# Altium schematic sheet WiFarm.SchDoc
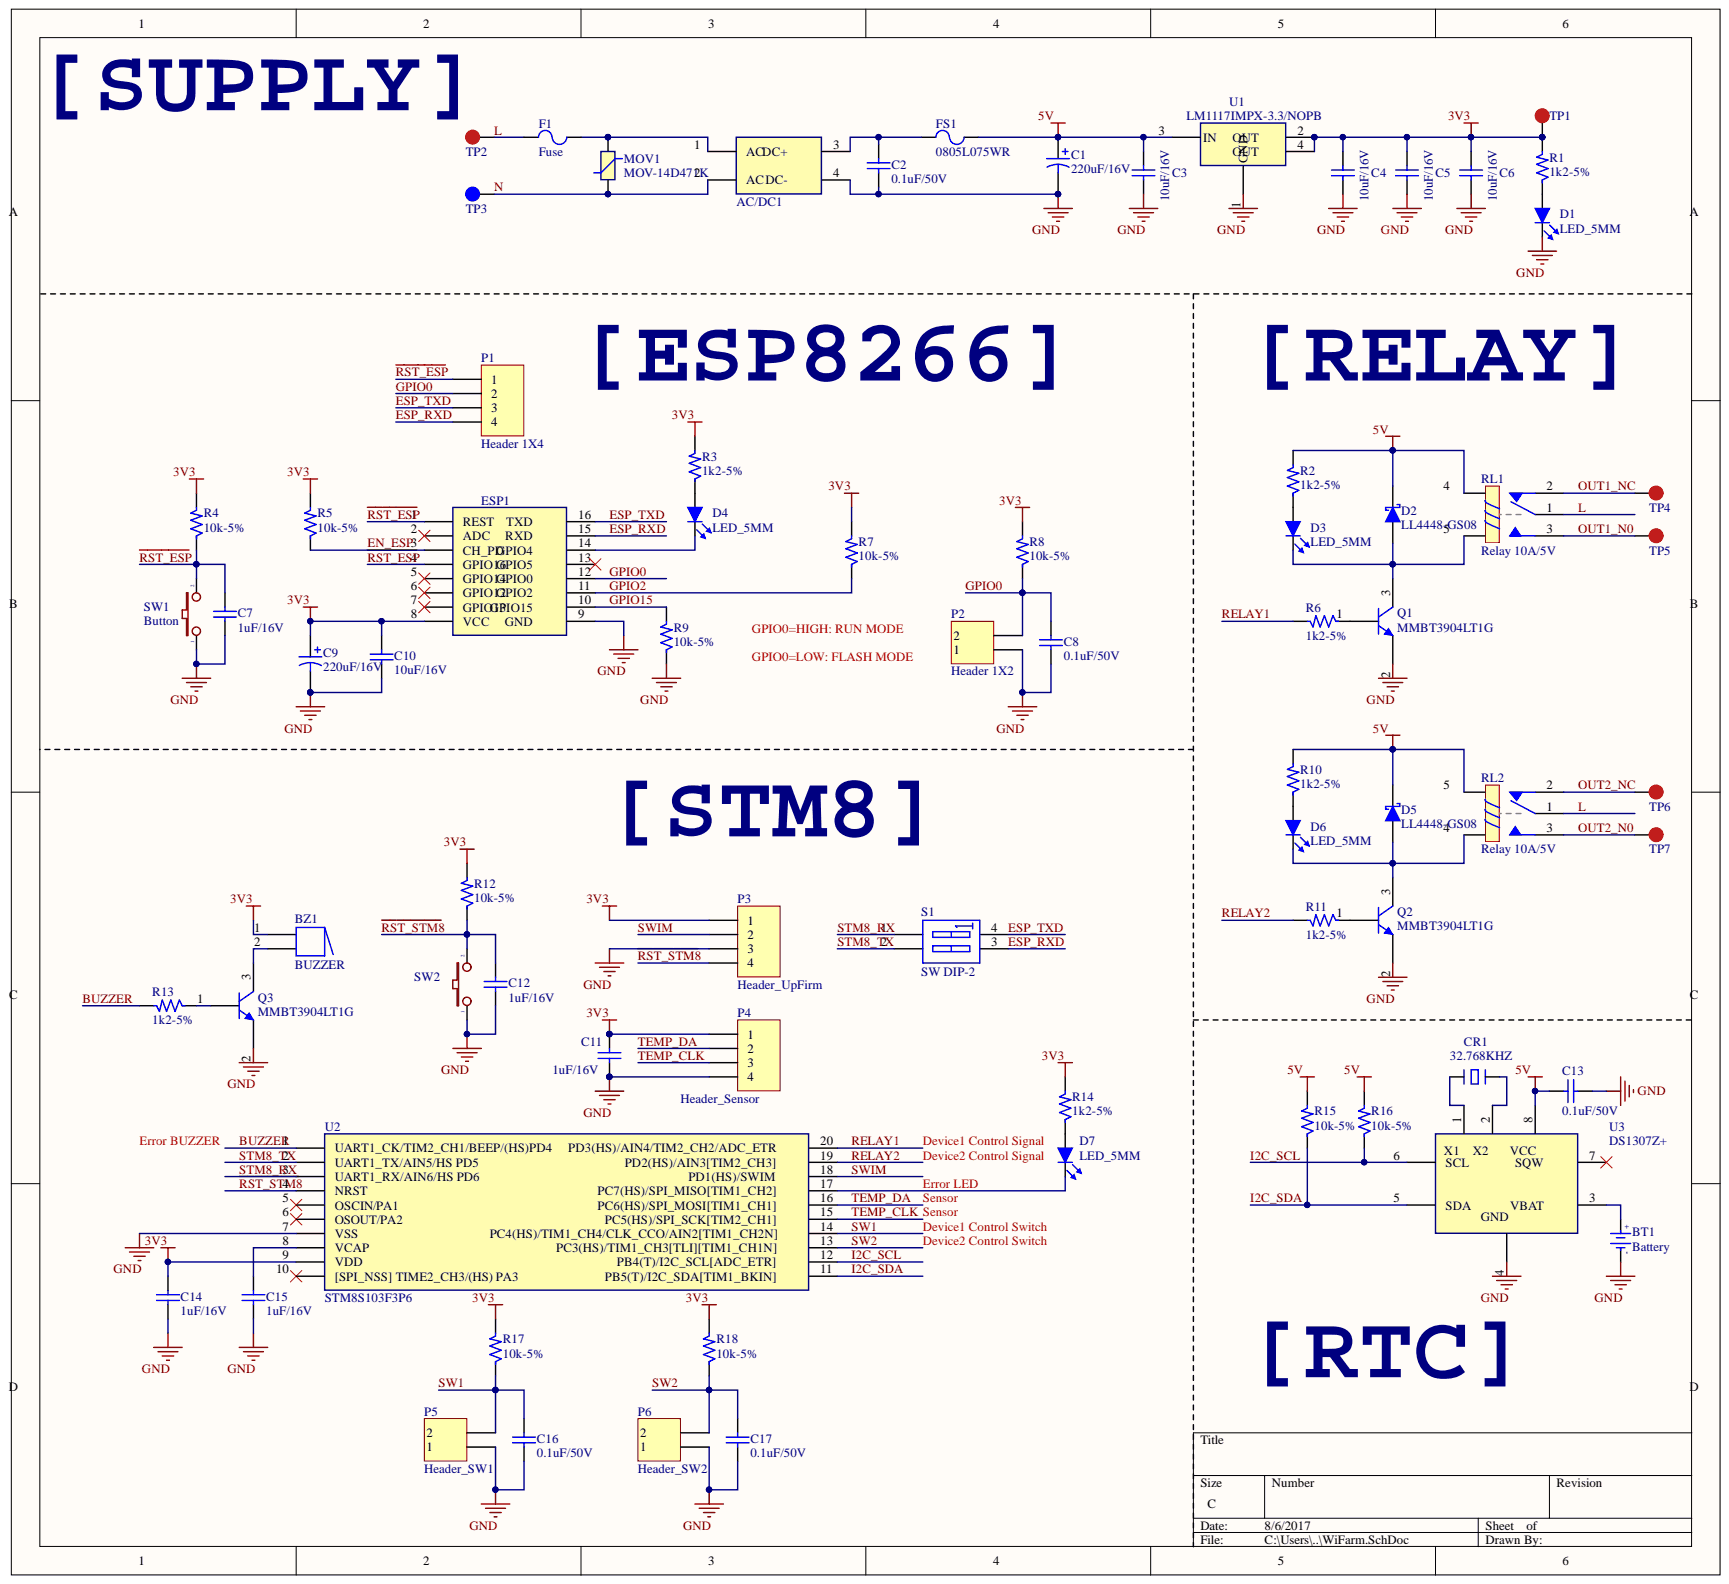
[diagram p0: WiFarm.SchDoc]

## Parts
AC/DC1  AC/DC_5V_0.7A  [AC/DC_5V_700mA]  (value not drawn)
BT1  Battery  [BATTERY HOLDER 1]
BZ1  BUZZER  [buzzer smd]
C1  220uF/16V  [RADIAL_6.3X12]
C2  0.1uF/50V  [CAP_SMD_0805]
C3  10uF/16V  [CAP_SMD_0805]
C4  10uF/16V  [CAP_SMD_0805]
C5  10uF/16V  [CAP_SMD_0805]
C6  10uF/16V  [CAP_SMD_0805]
C7  1uF/16V  [CAP_SMD_0805]
C8  0.1uF/50V  [CAP_SMD_0805]
C9  220uF/16V  [RADIAL_6.3X12]
C10  10uF/16V  [CAP_SMD_0805]
C11  1uF/16V  [CAP_SMD_0805]
C12  1uF/16V  [CAP_SMD_0805]
C13  0.1uF/50V  [CAP_SMD_0805]
C14  1uF/16V  [CAP_SMD_0805]
C15  1uF/16V  [CAP_SMD_0805]
C16  0.1uF/50V  [CAP_SMD_0805]
C17  0.1uF/50V  [CAP_SMD_0805]
CR1  32.768KHZ  [Cylindrical crystal - small]
D1  LED_5MM  [LED_5mm]
D2  LL4448-GS08  [SOD80C]
D3  LED_5MM  [LED_5mm]
D4  LED_5MM  [LED_5mm]
D5  LL4448-GS08  [SOD80C]
D6  LED_5MM  [LED_5mm]
D7  LED_5MM  [LED_5mm]
ESP1  ESP8266_07  [ESP8266_07]  (value not drawn)
F1  Fuse  [RESISTOR 1/4W (STAND)]
FS1  0805L075WR  [RES_SMD_0805]
MOV1  MOV-14D471K  [14D471]
P1  Header 1X4  [Header 1X4]
P2  Header 1X2  [Header 1X2]
P3  Header_UpFirm  [Header 1X4]
P4  Header_Sensor  [Header 1X4]
P5  Header_SW1  [Header 1X2]
P6  Header_SW2  [Header 1X2]
Q1  MMBT3904LT1G  [SOT-23]
Q2  MMBT3904LT1G  [SOT-23]
Q3  MMBT3904LT1G  [SOT-23]
R1  1k2-5%  [RES_SMD_0805]
R2  1k2-5%  [RES_SMD_0805]
R3  1k2-5%  [RES_SMD_0805]
R4  10k-5%  [RES_SMD_0805]
R5  10k-5%  [RES_SMD_0805]
R6  1k2-5%  [RES_SMD_0805]
R7  10k-5%  [RES_SMD_0805]
R8  10k-5%  [RES_SMD_0805]
R9  10k-5%  [RES_SMD_0805]
R10  1k2-5%  [RES_SMD_0805]
R11  1k2-5%  [RES_SMD_0805]
R12  10k-5%  [RES_SMD_0805]
R13  1k2-5%  [RES_SMD_0805]
R14  1k2-5%  [RES_SMD_0805]
R15  10k-5%  [RES_SMD_0805]
R16  10k-5%  [RES_SMD_0805]
R17  10k-5%  [RES_SMD_0805]
R18  10k-5%  [RES_SMD_0805]
RL1  Relay 10A/5V  [RELAY5PIN-10A]
RL2  Relay 10A/5V  [RELAY5PIN-10A]
S1  SW DIP-2  [DIP SWITCH 2]
SW1  Button  [BUTTON_SMD_2PIN]
SW2  SW_Reset  [BUTTON_SMD_2PIN]  (value not drawn)
TP1  Test Point  (value not drawn)
TP2  Test Point  (value not drawn)
TP3  Test Point  (value not drawn)
TP4  Test Point  (value not drawn)
TP5  Test Point  (value not drawn)
TP6  Test Point  (value not drawn)
TP7  Test Point  (value not drawn)
U1  LM1117IMPX-3.3/NOPB  [SOT223]
U2  STM8S103F3P6  [TSSOP20]
U3  DS1307Z+  [SOIC8_MOSFET]

## Nets
3V3: BZ1.1 C4.2 C5.2 C6.2 C9.1 C10.2 C11.2 C14.2 ESP1.8(VCC) P3.1 P4.1 R1.2 R3.2 R4.2 R5.2 R7.2 R8.2 R12.2 R14.2 R17.2 R18.2 TP1.1 U1.2 U1.4 U2.9(VDD)
5V: C1.1 C3.2 C13.2 D2.2 D5.2 FS1.2 R2.2 R10.2 R15.2 R16.2 RL1.4 RL2.5 U1.3(IN) U3.8
GND: AC/DC1.4 BT1.2 C1.2 C2.1 C3.1 C4.1 C5.1 C6.1 C7.1 C8.1 C9.2 C10.1 C11.1 C12.1 C13.1 C14.1 C15.1 C16.1 C17.1 D1.2 ESP1.9 P2.1 P3.3 P4.4 P5.1 P6.1 Q1.2 Q2.2 Q3.2 R9.1 SW1.1 SW2.1 U1.1(GND) U2.7(VSS) U3.4
BUZZER: R13.2 U2.1(UART1_CK/TIM2_CH1/BEEP/(HS)PD4)
EN_ESP: ESP1.3(CH_PD) R5.1
ESP_RXD: ESP1.15 P1.4 S1.3
ESP_TXD: ESP1.16 P1.3 S1.4
GPIO0: C8.2 ESP1.12 P1.2 P2.2 R8.1
GPIO2: ESP1.11 R7.1
GPIO15: ESP1.10 R9.2
I2C_SCL: R16.1 U2.12 U3.6(SCL)
I2C_SDA: R15.1 U2.11 U3.5(SDA)
L: F1.1 RL1.1 RL2.1 TP2.1
N: AC/DC1.2(AC_DC-) MOV1.1 TP3.1
OUT1_N0: RL1.3 TP5.1
OUT1_NC: RL1.2 TP4.1
OUT2_N0: RL2.3 TP7.1
OUT2_NC: RL2.2 TP6.1
~{RST_ESP}: C7.2 ESP1.1(REST) ESP1.4(GPIO16) P1.1 R4.1 SW1.2
~{RST_STM8}: C12.2 P3.4 R12.1 SW2.2 U2.4(NRST)
RELAY1: R6.2 U2.20
RELAY2: R11.2 U2.19
STM8_RX: S1.1 U2.3(UART1_RX/AIN6/HS_PD6)
STM8_TX: S1.2 U2.2(UART1_TX/AIN5/HS_PD5)
SW1: C16.2 P5.2 R17.1 U2.14
SW2: C17.2 P6.2 R18.1 U2.13
SWIM: P3.2 U2.18
TEMP_CLK: P4.3 U2.15
TEMP_DA: P4.2 U2.16
NetAC/DC1_1: AC/DC1.1(AC) F1.2 MOV1.2
NetAC/DC1_3: AC/DC1.3 C2.2 FS1.1
NetBT1_1: BT1.1 U3.3
NetBZ1_2: BZ1.2 Q3.3
NetC15_2: C15.2 U2.8(VCAP)
NetCR1_1: CR1.1 U3.2
NetCR1_2: CR1.2 U3.1
NetD1_1: D1.1 R1.1
NetD2_1: D2.1 D3.2 Q1.3 RL1.5
NetD3_1: D3.1 R2.1
NetD4_1: D4.1 R3.1
NetD4_2: D4.2 ESP1.14
NetD5_1: D5.1 D6.2 Q2.3 RL2.4
NetD6_1: D6.1 R10.1
NetD7_1: D7.1 R14.1
NetD7_2: D7.2 U2.17
NetQ1_1: Q1.1 R6.1
NetQ2_1: Q2.1 R11.1
NetQ3_1: Q3.1 R13.1

Not connected: ESP1 2(ADC) 5(GPIO14) 6(GPIO12) 7(GPIO13) 13; U2 5(OSCIN/PA1) 6(OSOUT/PA2) 10([SPI_NSS]_TIME2_CH3/(HS)_PA3); U3 7

## Library data of the parts
AC/DC1 = AC/DC_5V_0.7A: AC/DC Converter 5V 700mA; Component Kind: Standard; ComponentLink1URL: http://www.dientuachau.com/ac-dc-5v-700; Library Name: LIF_DIF_Library.IntLib
BT1 = Battery: Battery 3VDC; Value: PIN 3V; Component Kind: Standard; Library Name: LIF_DIF_Library.IntLib
BZ1 = BUZZER: AUDIO MAGNETIC XDCR 3-7V TH; Manufacturer: PUI Audio, Inc.; Manufacturer Part Number: AT-1224-TWT-5V-2-R; Current - Supply: 40mA; Driver Circuitry: Transducer, Externally Driven; Frequency: 2.4kHz; Input Type: Peak-Peak Signal; Operating Mode: Single Tone; Port Location: Top; Ratings: Washable; Sound Pressure Level (SPL): 87dB @ 5V, 10cm; Voltage - Rated: 5V; Voltage Range: 3 ~ 7V; Approvals: -; Category: Audio Products; Component Kind: Standard; ComponentLink1Description: http://www.puiaudio.com/pdf/AT-1224-TWT-5V-2-R.pdf; ComponentLink1URL: http://www.puiaudio.com/pdf/AT-1224-TWT-5V-2-R.pdf; Duration: -; Height - Seated (Max): 0.366" (9.30mm); Mounting Type: Through Hole; Operating Temperature: -30°C ~ 70°C; Packaging: Tray; Part Status: Active; Pricing 1: 1=0.55, 10=0.5, 100=0.4, 500=0.332, 1000=0.29, 5000=0.26 (USD); RoHS: RoHS Compliant; Size / Dimension: 0.472" Dia (12.00mm); Stock 1: 54169; Supplier 1: Digi-Key; Supplier Part Number 1: 668-1470-ND; Technology: Magnetic; Termination: PC Pins; Library Name: LIF_DIF_Library.IntLib
C1 C9 = 100uF/25V: CAP ALUM 100UF 20% 25V RADIAL; Manufacturer: Panasonic Electronic Components; Manufacturer Part Number: ECA-1EM101; Capacitance: 100µF; Lifetime @ Temp.: 2000 Hrs @ 85°C; Polarization: Polar; Ripple Current - High Frequency: 306mA @ 10kHz; Ripple Current - Low Frequency: 180mA @ 120Hz; Applications: General Purpose; Category: Capacitors; Component Kind: Standard; ComponentLink1Description: https://industrial.panasonic.com/cdbs/www-data/pdf/RDF0000/ABA0000C1218.pdf; ComponentLink1URL: https://industrial.panasonic.com/cdbs/www-data/pdf/RDF0000/ABA0000C1218.pdf; ESR (Equivalent Series Resistance): -; Height - Seated (Max): 0.480" (12.20mm); Impedance: -; Lead Spacing: 0.098" (2.50mm); Mounting Type: Through Hole; Operating Temperature: -40°C ~ 85°C; Package / Case: Radial, Can; Packaging: Bulk; Part Status: Active; Pricing 1: 1=0.28, 10=0.191, 100=0.097, 500=0.06634, 1000=0.05868, 2500=0.05613, 5000=0.05103 (USD); RoHS: RoHS Compliant; Size / Dimension: 0.248" Dia (6.30mm); Stock 1: 8044; Supplier 1: Digi-Key; Supplier Part Number 1: P5152-ND; Surface Mount Land Size: -; Tolerance: ±20%; Voltage Rating: 25V; Library Name: LIF_DIF_Library.IntLib
C2 C8 C13 C16 C17 = 0.1uF/50V-10%-0805: CAP CER 0.1UF 50V X7R 0805; Manufacturer: Yageo; Manufacturer Part Number: CC0805KRX7R9BB104; Capacitance: 0.10µF; Tolerance: ±10%; Applications: General Purpose; Category: Capacitors; Component Kind: Standard; ComponentLink1Description: http://www.yageo.com/documents/recent/UPY-GPHC_X7R_6.3V-to-50V_14.pdf; ComponentLink1URL: http://www.yageo.com/documents/recent/UPY-GPHC_X7R_6.3V-to-50V_14.pdf; Features: -; Height - Seated (Max): -; Lead Spacing: -; Lead Style: -; Mounting Type: Surface Mount, MLCC; Operating Temperature: -55°C ~ 125°C; Package / Case: 0805 (2012 Metric); Packaging: Cut Tape (CT); Pricing 1: 1=0.1, 10=0.051, 25=0.0356, 100=0.0232, 250=0.01896, 500=0.01622, 1000=0.01263 (USD); Ratings: -; RoHS: RoHS Compliant; Size / Dimension: 0.079" L x 0.049" W (2.00mm x 1.25mm); Stock 1: 931677; Supplier 1: Digi-Key; Supplier Part Number 1: 311-1140-1-ND; Temperature Coefficient: X7R; Thickness (Max): 0.033" (0.85mm); Voltage - Rated: 50V; Library Name: LIF_DIF_Library.IntLib
C3-C6 C10 = 10uF/16V-10%-0805: CAP CER 10UF 16V X5R 0805; Manufacturer: Yageo; Manufacturer Part Number: CC0805KKX5R7BB106; Capacitance: 10µF; Tolerance: ±10%; Applications: General Purpose; Category: Capacitors; Component Kind: Standard; ComponentLink1Description: http://www.yageo.com/documents/recent/UPY-GPHC_X5R_4V-to-50V-21.pdf; ComponentLink1URL: http://www.yageo.com/documents/recent/UPY-GPHC_X5R_4V-to-50V-21.pdf; Features: -; Height - Seated (Max): -; Lead Spacing: -; Lead Style: -; Mounting Type: Surface Mount, MLCC; Operating Temperature: -55°C ~ 85°C; Package / Case: 0805 (2012 Metric); Packaging: Cut Tape (CT); Pricing 1: 1=0.21, 10=0.141, 25=0.102, 100=0.0715, 250=0.05792, 500=0.0511, 1000=0.04343 (USD); Ratings: -; RoHS: RoHS Compliant; Size / Dimension: 0.079" L x 0.049" W (2.00mm x 1.25mm); Stock 1: 114; Supplier 1: Digi-Key; Supplier Part Number 1: 311-1865-1-ND; Temperature Coefficient: X5R; Thickness (Max): 0.057" (1.45mm); Voltage - Rated: 16V; Library Name: LIF_DIF_Library.IntLib
C7 C11 C12 C14 C15 = 1uF/16V-10%-0805: CAP CER 1UF 16V X7R 0805; Manufacturer: Yageo; Manufacturer Part Number: CC0805KKX7R7BB105; Capacitance: 1µF; Tolerance: ±10%; Applications: General Purpose; Category: Capacitors; Component Kind: Standard; ComponentLink1Description: http://www.yageo.com/documents/recent/UPY-GPHC_X7R_6.3V-to-50V_14.pdf; ComponentLink1URL: http://www.yageo.com/documents/recent/UPY-GPHC_X7R_6.3V-to-50V_14.pdf; Features: -; Height - Seated (Max): -; Lead Spacing: -; Lead Style: -; Mounting Type: Surface Mount, MLCC; Operating Temperature: -55°C ~ 125°C; Package / Case: 0805 (2012 Metric); Packaging: Cut Tape (CT); Pricing 1: 1=0.1, 10=0.069, 25=0.0492, 100=0.0318, 250=0.026, 500=0.02224, 1000=0.01733 (USD); Ratings: -; RoHS: RoHS Compliant; Size / Dimension: 0.079" L x 0.049" W (2.00mm x 1.25mm); Stock 1: 48; Supplier 1: Digi-Key; Supplier Part Number 1: 311-1365-1-ND; Temperature Coefficient: X7R; Thickness (Max): 0.049" (1.25mm); Voltage - Rated: 16V; Library Name: LIF_DIF_Library.IntLib
CR1 = 32.768KHZ: CRYSTAL 32.7680KHZ 12.5PF SMD; Manufacturer: Abracon LLC; Manufacturer Part Number: AB26TRB-32.768KHZ-T; ESR (Equivalent Series Resistance): 50 kOhm; Frequency Tolerance: ±20ppm; Operating Mode: Fundamental; Type: kHz Crystal (Tuning Fork); Category: Crystals, Oscillators, Resonators; Component Kind: Standard; ComponentLink1Description: http://www.abracon.com/Resonators/AB26TRB.pdf; ComponentLink1URL: http://www.abracon.com/Resonators/AB26TRB.pdf; Frequency: 32.768kHz; Frequency Stability: -; Height - Seated (Max): 0.075" (1.90mm); Load Capacitance: 12.5pF; Mounting Type: Surface Mount; Operating Temperature: -40°C ~ 85°C; Package / Case: Cylindrical Can, Radial; Packaging: Cut Tape (CT); Part Status: Active; Pricing 1: 1=0.5, 10=0.413, 50=0.3712, 100=0.33, 500=0.3135, 1000=0.264 (USD); Ratings: -; RoHS: RoHS Compliant; Size / Dimension: 0.075" Dia x 0.236" L (1.90mm x 6.00mm); Stock 1: 41578; Supplier 1: Digi-Key; Supplier Part Number 1: 535-9033-1-ND; Library Name: LIF_DIF_Library.IntLib
D1 D3 D4 D6 D7 = LED_5MM: LED BLUE 5MM; Manufacturer: Lite-On Inc; Manufacturer Part Number: LTST-C171TBKT; Component Kind: Standard; Digi-Key Part Number: 160-1645-1-ND; Unit Price: 0.08100; Library Name: LIF_DIF_Library.IntLib
D2 D5 = LL4448-GS08: DIODE GEN PURP 75V 150MA SOD80; Manufacturer: Vishay Semiconductor Diodes Division; Capacitance @ Vr, F: 4pF @ 0V, 1MHz; Current - DC Forward (If) (Max): 10mA; Current - Output / Channel: 50mA; Current - Reverse Leakage @ Vr: 5µA @ 75V; Current Transfer Ratio (Max): 600% @ 500µA; Current Transfer Ratio (Min): 100% @ 500µA; Diode Type: Standard; Input Type: DC; Number of Channels: 1; Output Type: Transistor; Rise / Fall Time (Typ): 4µs, 3µs; Speed: Small Signal =< 200mA (Io), Any Speed; Vce Saturation (Max): 200mV; Voltage - Forward (Vf) (Max) @ If: 720mV @ 5mA; Voltage - Forward (Vf) (Typ): 1.2V; Voltage - Isolation: 5000Vrms; Voltage - Output (Max): 80V; Category: Discrete Semiconductor Products; Component Kind: Standard; ComponentLink1Description: http://www.vishay.com/docs/85557/ll4148.pdf; ComponentLink1URL: http://www.vishay.com/docs/85557/ll4148.pdf; ComponentLink2Description: https://cdn.sharpsde.com/fileadmin/products/Optoelectronics/Isolation%20Devices/Specs%20Photocoupler/PC8171xNIP0X_24Nov09_Spec_ED-09P026A.pdf; ComponentLink2URL: https://cdn.sharpsde.com/fileadmin/products/Optoelectronics/Isolation%20Devices/Specs%20Photocoupler/PC8171xNIP0X_24Nov09_Spec_ED-09P026A.pdf; Current - Average Rectified (Io): 150mA; Manufacturer Part Number: LL4448-GS08; Mounting Type: Surface Mount; Operating Temperature: -30°C ~ 100°C; Operating Temperature - Junction: -65°C ~ 175°C; Package / Case: DO-213AC, MINI-MELF, SOD-80; Packaging: Cut Tape (CT); Part Status: Active; Pricing 1: 1=0.15, 10=0.133, 25=0.1272, 100=0.0727, 250=0.05388, 500=0.04472, 1000=0.03049 (USD); Pricing 2: 1=0.89, 10=0.693, 25=0.5744, 100=0.495, 250=0.4356, 500=0.3762, 1000=0.297 (USD); RoHS: RoHS Compliant; Stock 1: 32666; Stock 2: 39900; Supplier 1: Digi-Key; Supplier 2: Digi-Key; Supplier Device Package: SOD-80 MiniMELF; Supplier Part Number 1: LL4448-GS08CT-ND; Supplier Part Number 2: 425-2753-1-ND; Turn On / Turn Off Time (Typ): -; Voltage - DC Reverse (Vr) (Max): 75V; Library Name: LIF_DIF_Library.IntLib
ESP1 = ESP8266_07: ESP8266 SMT MODULE; Manufacturer: Adafruit Industries LLC; Manufacturer Part Number: 2491; Antenna Type: Integrated, Trace; Current - Receiving: 50mA ~ 56mA; Current - Transmitting: 120mA ~ 170mA; Data Rate: 72.2Mbps; Frequency: 2.4GHz; Power - Output: 20.5dBm; Protocol: 802.11b/g/n; RF Family/Standard: WiFi; Sensitivity: -98dBm; Serial Interfaces: I²C, I²S, SPI, UART; Voltage - Supply: 3 V ~ 3.6 V; Category: RF/IF and RFID; Component Kind: Standard; ComponentLink1Description: https://www.adafruit.com/images/product-files/2471/0A-ESP8266__Datasheet__EN_v4.3.pdf; ComponentLink1URL: https://www.adafruit.com/images/product-files/2471/0A-ESP8266__Datasheet__EN_v4.3.pdf; Memory Size: -; Modulation: -; Mounting Type: Surface Mount; Operating Temperature: -40°C ~ 125°C; Package / Case: Module; Packaging: Bulk; Part Status: Active; Pricing 1: 1=6.95 (USD); RoHS: RoHS Compliant; Stock 1: 152; Supplier 1: Digi-Key; Supplier Part Number 1: 1528-1438-ND; Library Name: LIF_DIF_Library.IntLib
F1 = Fuse_AC: Fuse 500mA; Component Kind: Standard; Library Name: LIF_DIF_Library.IntLib
FS1 = Fuse_0805L075WR: PTC RESETTABLE 6V .750A SMD 0805; Manufacturer: Littelfuse Inc.; Approvals: cURus, TUV; Current - Max: 40A; Resistance - Initial (Ri) (Min): 90 mOhm; Resistance - Post Trip (R1) (Max): 350 mOhm; Time to Trip: 200ms; Category: Circuit Protection; Component Kind: Standard; ComponentLink1Description: http://www.littelfuse.com/~/media/electronics/datasheets/resettable_ptcs/littelfuse_ptc_0805l_datasheet.pdf.pdf; ComponentLink1URL: http://www.littelfuse.com/~/media/electronics/datasheets/resettable_ptcs/littelfuse_ptc_0805l_datasheet.pdf.pdf; Height - Seated (Max): -; Lead Spacing: -; Manufacturer Part Number: 0805L075WR; Mounting Type: Surface Mount; Operating Temperature: -40°C ~ 85°C; Package / Case: 0805 (2012 Metric), Concave; Packaging: Cut Tape (CT); Part Status: Active; Pricing 1: 1=2.54, 5=2.422, 10=2.3, 50=1.8562, 100=1.5737, 250=1.53332, 500=1.2912, 1000=1.14998 (USD); Ratings: -; Resistance - 25°C (Typ): -; RoHS: RoHS Compliant; Size / Dimension: 0.083" L x 0.053" W (2.10mm x 1.35mm); Stock 1: 5069; Supplier 1: Digi-Key; Supplier Part Number 1: F2773CT-ND; Thickness (Max): 0.030" (0.75mm); Type: Polymeric; Voltage - Max: 6V; Library Name: LIF_DIF_Library.IntLib
MOV1 = MOV-14D471K: VARISTOR 470V 4.5KA DISC 14MM; Manufacturer: Bourns Inc.; Capacitance @ Frequency: 430pF @ 1kHz; Energy: 125J; Maximum AC Volts: 300VAC; Maximum DC Volts: 385VDC; Number of Circuits: 1; Varistor Voltage (Max): 517V; Varistor Voltage (Min): 423V; Category: Circuit Protection; Component Kind: Standard; ComponentLink1Description: http://www.bourns.com/docs/Product-Datasheets/MOV14D.pdf; ComponentLink1URL: http://www.bourns.com/docs/Product-Datasheets/MOV14D.pdf; Manufacturer Part Number: MOV-14D471K; Mounting Type: Through Hole; Operating Temperature: -40°C ~ 85°C (TA); Package / Case: Disc 14mm; Packaging: Bulk; Part Status: Active; Pricing 1: 1=0.47, 10=0.367, 25=0.3096, 100=0.252, 250=0.2088, 500=0.1728, 1000=0.1296, 2500=0.1188, 5000=0.1116 (USD); RoHS: RoHS Compliant; Stock 1: 6650; Supplier 1: Digi-Key; Supplier Part Number 1: MOV-14D471K-ND; Varistor Voltage (Typ): 470V; Library Name: LIF_DIF_Library.IntLib
P1 P3 P4 = Header 1X4: Header, 4-Pin; Component Kind: Standard; Library Name: LIF_DIF_Library.IntLib
P2 P5 P6 = Header 1X2: Header, 2-Pin; Component Kind: Standard; Library Name: LIF_DIF_Library.IntLib
Q1-Q3 = MMBT3904LT1G: TRANS NPN 40V 0.2A SOT23; Manufacturer: ON Semiconductor; Current - Collector (Ic) (Max): 200mA; DC Current Gain (hFE) (Min) @ Ic, Vce: 100 @ 10mA, 1V; Frequency - Transition: 300MHz; Power - Max: 300mW; Vce Saturation (Max) @ Ib, Ic: 300mV @ 5mA, 50mA; Category: Discrete Semiconductor Products; Component Kind: Standard; ComponentLink1Description: http://www.onsemi.com/pub_link/Collateral/MMBT3904LT1-D.PDF; ComponentLink1URL: http://www.onsemi.com/pub_link/Collateral/MMBT3904LT1-D.PDF; Current - Collector Cutoff (Max): -; Manufacturer Part Number: MMBT3904LT1G; Mounting Type: Surface Mount; Operating Temperature: -55°C ~ 150°C (TJ); Package / Case: TO-236-3, SC-59, SOT-23-3; Packaging: Digi-Reel®; Part Status: Active; Pricing 1: 1=0.11, 10=0.103, 100=0.0562, 500=0.03456, 1000=0.02356 (USD); RoHS: RoHS Compliant; Stock 1: 132222; Supplier 1: Digi-Key; Supplier Device Package: SOT-23-3 (TO-236); Supplier Part Number 1: MMBT3904LT1GOSDKR-ND; Transistor Type: NPN; Voltage - Collector Emitter Breakdown (Max): 40V; Library Name: LIF_DIF_Library.IntLib
R1-R3 R6 R10 R11 R13 R14 = 1k2-5%-0805: RES SMD 1.2K OHM 5% 1/8W 0805; Manufacturer: Yageo; Manufacturer Part Number: RC0805JR-071K2L; Power (Watts): 0.125W, 1/8W; Temperature Coefficient: ±100ppm/°C; Category: Resistors; Component Kind: Standard; ComponentLink1Description: http://www.yageo.com/documents/recent/PYu-RC_Group_51_RoHS_L_7.pdf; ComponentLink1URL: http://www.yageo.com/documents/recent/PYu-RC_Group_51_RoHS_L_7.pdf; Composition: Thick Film; Failure Rate: -; Features: Moisture Resistant; Height - Seated (Max): 0.024" (0.60mm); Operating Temperature: -55°C ~ 155°C; Package / Case: 0805 (2012 Metric); Packaging: Cut Tape (CT); Part Status: Active; Pricing 1: 1=0.1, 10=0.018, 100=0.0073, 1000=0.00326, 2500=0.00283 (USD); Resistance (Ohms): 1.2k; RoHS: RoHS Compliant; Size / Dimension: 0.079" L x 0.049" W (2.00mm x 1.25mm); Stock 1: 85871; Supplier 1: Digi-Key; Supplier Device Package: 0805; Supplier Part Number 1: 311-1.2KARCT-ND; Tolerance: ±5%; Library Name: LIF_DIF_Library.IntLib
R4 R5 R7-R9 R12 R15-R18 = 10k-5%-0805: RES SMD 10K OHM 5% 1/8W 0805; Manufacturer: Yageo; Manufacturer Part Number: RC0805JR-0710KL; Power (Watts): 0.125W, 1/8W; Temperature Coefficient: ±100ppm/°C; Category: Resistors; Component Kind: Standard; ComponentLink1Description: http://www.yageo.com/documents/recent/PYu-RC_Group_51_RoHS_L_7.pdf; ComponentLink1URL: http://www.yageo.com/documents/recent/PYu-RC_Group_51_RoHS_L_7.pdf; Composition: Thick Film; Failure Rate: -; Features: Moisture Resistant; Height - Seated (Max): 0.024" (0.60mm); Operating Temperature: -55°C ~ 155°C; Package / Case: 0805 (2012 Metric); Packaging: Cut Tape (CT); Part Status: Active; Pricing 1: 1=0.1, 10=0.018, 100=0.0073, 1000=0.00326, 2500=0.00283 (USD); Resistance (Ohms): 10k; RoHS: RoHS Compliant; Size / Dimension: 0.079" L x 0.049" W (2.00mm x 1.25mm); Stock 1: 1331399; Supplier 1: Digi-Key; Supplier Device Package: 0805; Supplier Part Number 1: 311-10KARCT-ND; Tolerance: ±5%; Library Name: LIF_DIF_Library.IntLib
RL1 RL2 = Relay 10A: Relay SPDT,10A - 12VDC 5 Pin; Value: Relay SPDT, 10A, 12V; : Relay SPDT, 10A, 24V; Component Kind: Standard; Library Name: LIF_DIF_Library.IntLib
S1 = SW DIP-2: DIP Switch, 2 Position, SPST; Component Kind: Standard; Library Name: LIF_DIF_Library.IntLib
SW1 SW2 = SW: Switch button 2 pin; Component Kind: Standard; Name: Button; Library Name: LIF_DIF_Library.IntLib
TP3 = Test Point_1: Component Kind: Standard; Description: Test Point; Value: Test Point; Library Name: LIF_DIF_Library.IntLib
U1 = LM1117IMPX-3.3/NOPB: IC REG LDO 3.3V 0.8A SOT223; Manufacturer: Texas Instruments; Current - Output: 800mA; Current - Supply: 5mA ~ 10mA; Output Configuration: Positive; Output Type: Fixed; Protection Features: Over Current, Over Temperature; PSRR: 75dB (120Hz); Regulator Topology: Positive Fixed; Voltage - Dropout (Typical): 1.2V @ 800mA; Voltage - Input (Max): 15V; Category: Integrated Circuits (ICs); Component Kind: Standard; ComponentLink1Description: http://www.ti.com/lit/ds/symlink/lm1117.pdf; ComponentLink1URL: http://www.ti.com/lit/ds/symlink/lm1117.pdf; Control Features: -; Manufacturer Part Number: LM1117IMPX-3.3/NOPB; Mounting Type: Surface Mount; Operating Temperature: -40°C ~ 125°C; Package / Case: TO-261-4, TO-261AA; Packaging: Cut Tape (CT); Part Status: Active; Pricing 1: 1=1.04, 10=0.927, 100=0.7225, 500=0.59688, 1000=0.47123 (USD); RoHS: RoHS Compliant; Stock 1: 25761; Supplier 1: Digi-Key; Supplier Device Package: SOT-223-4; Supplier Part Number 1: LM1117IMPX-3.3/NOPBCT-ND; Voltage - Output: 3.3V; Voltage - Output (Max): -; Voltage - Output (Min/Fixed): 3.3V; Voltage Dropout (Max): 1.2V @ 800mA; Library Name: LIF_DIF_Library.IntLib
U2 = STM8S103F3P6: IC MCU 8BIT 8KB FLASH 20TSSOP; Manufacturer: STMicroelectronics; Connectivity: I²C, IrDA, LIN, SPI, UART/USART; Core Processor: STM8; Core Size: 8-Bit; Data Converters: A/D 5x10b; EEPROM Size: 640 x 8; Number of I/O: 16; Oscillator Type: Internal; Peripherals: Brown-out Detect/Reset, POR, PWM, WDT; Program Memory Size: 8KB (8K x 8); RAM Size: 1K x 8; Speed: 16MHz; Voltage - Supply (Vcc/Vdd): 2.95 V ~ 5.5 V; Category: Integrated Circuits (ICs); Component Kind: Standard; ComponentLink1Description: http://www.st.com/content/ccc/resource/technical/document/datasheet/ce/13/13/03/a9/a4/42/8f/CD00226640.pdf/files/CD00226640.pdf/jcr:content/translations/en.CD00226640.pdf; ComponentLink1URL: http://www.st.com/content/ccc/resource/technical/document/datasheet/ce/13/13/03/a9/a4/42/8f/CD00226640.pdf/files/CD00226640.pdf/jcr:content/translations/en.CD00226640.pdf; Manufacturer Part Number: STM8S103F3P6; Operating Temperature: -40°C ~ 85°C (TA); Package / Case: 20-TSSOP (0.173", 4.40mm Width); Packaging: Tube; Part Status: Active; Pricing 1: 1=1.43, 10=1.28, 100=0.9982, 500=0.8246, 1000=0.651 (USD); Program Memory Type: FLASH; RoHS: RoHS Compliant; Stock 1: 0; Supplier 1: Digi-Key; Supplier Device Package: 20-TSSOP; Supplier Part Number 1: 497-11579-ND; Library Name: LIF_DIF_Library.IntLib
U3 = DS1307Z+: IC RTC CLK/CALENDAR I2C 8-SOIC; Manufacturer: Maxim Integrated; Current - Timekeeping (Max): 200µA @ 5V; Date Format: YY-MM-DD-dd; Interface: I²C, 2-Wire Serial; Memory Size: 56B; Time Format: HH:MM:SS (12/24 hr); Voltage - Supply: 4.5 V ~ 5.5 V; Voltage - Supply, Battery: 2 V ~ 3.5 V; Category: Integrated Circuits (ICs); Component Kind: Standard; ComponentLink1Description: http://datasheets.maximintegrated.com/en/ds/DS1307.pdf; ComponentLink1URL: http://datasheets.maximintegrated.com/en/ds/DS1307.pdf; Features: Leap Year, NVSRAM, Square Wave Output; Manufacturer Part Number: DS1307Z+; Mounting Type: Surface Mount; Operating Temperature: 0°C ~ 70°C; Package / Case: 8-SOIC (0.154", 3.90mm Width); Packaging: Tube; Part Status: Active; Pricing 1: 1=3.26, 10=3.097, 25=2.86, 50=2.717, 100=2.45, 250=2.32752, 1000=1.70136 (USD); RoHS: RoHS Compliant; Stock 1: 7160; Supplier 1: Digi-Key; Supplier Device Package: 8-SOIC; Supplier Part Number 1: DS1307Z+-ND; Type: Clock/Calendar; Library Name: LIF_DIF_Library.IntLib
TP1 TP2 TP4-TP7 = Test Point: Component Kind: Standard; Description: Test Point; Value: Test Point; Library Name: LIF_DIF_Library.IntLib
